# Altium schematic sheet projectnew.schdoc
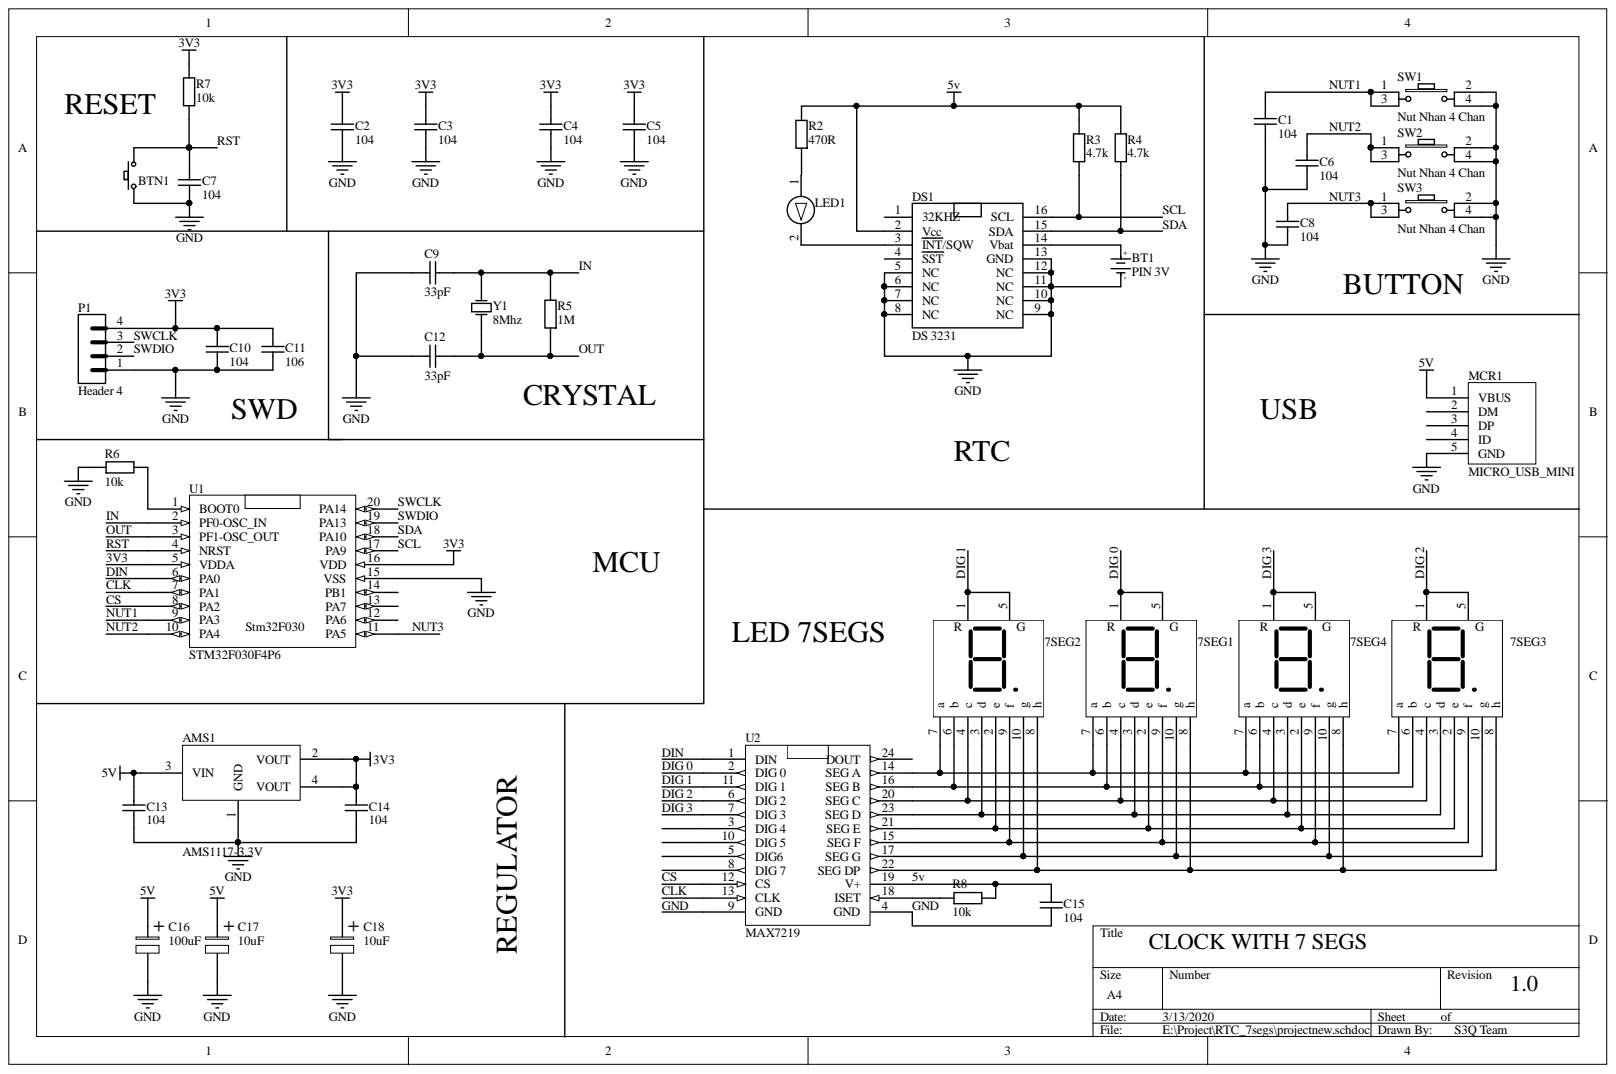
[diagram p0: projectnew.schdoc]

## Parts
7SEG1  Led 7 Thanh 2.3 Inch Anot  [LED 7 THANH X 1.8INCH]  (value not drawn)
7SEG2  Led 7 Thanh 2.3 Inch Anot  [LED 7 THANH X 1.8INCH]  (value not drawn)
7SEG3  Led 7 Thanh 2.3 Inch Anot  [LED 7 THANH X 1.8INCH]  (value not drawn)
7SEG4  Led 7 Thanh 2.3 Inch Anot  [LED 7 THANH X 1.8INCH]  (value not drawn)
AMS1  AMS1117-3.3V  [SOT223-4N]
BT1  PIN 3V  [DE PINCR1220]
BTN1  Button  [SW-SMD]  (value not drawn)
C1  104  [Tu 0805]
C2  104  [tu 0805]
C3  104  [tu 0805]
C4  104  [tu 0805]
C5  104  [tu 0805]
C6  104  [Tu 0805]
C7  104  [tu 0805]
C8  104  [Tu 0805]
C9  33pF  [tu 0805]
C10  104  [tu 0805]
C11  106  [Tu 0805]
C12  33pF  [tu 0805]
C13  104  [tu 0805]
C14  104  [tu 0805]
C15  104  [Tu 0805]
C16  100uF  [tu nhom 6.3 (d)]
C17  10uF  [tu nhom 4 (b)]
C18  10uF  [tu nhom 4 (b)]
DS1  DS 3231  [SOP16]
LED1  (no value)  [led 0805]
MCR1  MICRO_USB_MINI  [micro USB MK5P_new]
P1  Header 4  [JP4]
R2  470R  [Tro SMD 0805]
R3  4.7k  [Tro SMD 0805]
R4  4.7k  [Tro SMD 0805]
R5  1M  [tro smd 0805]
R6  10k  [tro smd 0805]
R7  10k  [tro smd 0805]
R8  10k  [tro smd 0805]
SW1  Nut Nhan 4 Chan  [SW4]
SW2  Nut Nhan 4 Chan  [SW4]
SW3  Nut Nhan 4 Chan  [SW4]
U1  STM32F030F4P6  [TSSOP20]
U2  MAX7219  [SOIC127P1030X265-24M]
Y1  8Mhz  [THACH ANH CAM]

## Nets
3V3: AMS1.2(VOUT) AMS1.4(VOUT) C2.1 C3.1 C4.1 C5.1 C10.1 C11.1 C14.1 C18.1 P1.4 R7.2 U1.5(VDDA) U1.16(VDD)
5v: AMS1.3(VIN) C13.1 C15.2 C16.1 C17.1 DS1.2(Vcc) MCR1.1(VBUS) R2.2 R3.2 R4.2 R8.2 U2.19(V+)
CLK: U1.7(PA1) U2.13(CLK)
CS: U1.8(PA2) U2.12(CS)
DIG 0: 7SEG1.1 7SEG1.5 U2.2(DIG_0)
DIG 1: 7SEG2.1 7SEG2.5 U2.11(DIG_1)
DIG 2: 7SEG3.1 7SEG3.5 U2.6(DIG_2)
DIG 3: 7SEG4.1 7SEG4.5 U2.7(DIG_3)
DIN: U1.6(PA0) U2.1(DIN)
GND: AMS1.1(GND) BT1.2 BTN1.1 C1.2 C2.2 C3.2 C4.2 C5.2 C6.2 C7.2 C8.2 C9.2 C10.2 C11.2 C12.2 C13.2 C14.2 C15.1 C16.2 C17.2 C18.2 DS1.5(NC) DS1.6(NC) DS1.7(NC) DS1.8(NC) DS1.9(NC) DS1.10(NC) DS1.11(NC) DS1.12(NC) DS1.13(GND) MCR1.5(GND) P1.1 R6.1 SW1.2 SW1.4 SW2.2 SW2.4 SW3.2 SW3.4 U1.15(VSS) U2.4(GND) U2.9(GND)
IN: C9.1 R5.2 U1.2(PF0-OSC_IN) Y1.2
NUT1: C1.1 SW1.1 SW1.3 U1.9(PA3)
NUT2: C6.1 SW2.1 SW2.3 U1.10(PA4)
NUT3: C8.1 SW3.1 SW3.3 U1.11(PA5)
OUT: C12.1 R5.1 U1.3(PF1-OSC_OUT) Y1.1
RST: BTN1.2 C7.1 R7.1 U1.4(NRST)
SCL: DS1.16(SCL) R3.1 U1.17(PA9)
SDA: DS1.15(SDA) R4.1 U1.18(PA10)
SWCLK: P1.3 U1.20(PA14)
SWDIO: P1.2 U1.19(PA13)
Net7SEG1_2: 7SEG1.2(e) 7SEG2.2(e) 7SEG3.2(e) 7SEG4.2(e) U2.21(SEG_E)
Net7SEG1_3: 7SEG1.3(d) 7SEG2.3(d) 7SEG3.3(d) 7SEG4.3(d) U2.23(SEG_D)
Net7SEG1_4: 7SEG1.4(c) 7SEG2.4(c) 7SEG3.4(c) 7SEG4.4(c) U2.20(SEG_C)
Net7SEG1_6: 7SEG1.6(b) 7SEG2.6(b) 7SEG3.6(b) 7SEG4.6(b) U2.16(SEG_B)
Net7SEG1_7: 7SEG1.7(a) 7SEG2.7(a) 7SEG3.7(a) 7SEG4.7(a) U2.14(SEG_A)
Net7SEG1_8: 7SEG1.8(h) 7SEG2.8(h) 7SEG3.8(h) 7SEG4.8(h) U2.22(SEG_DP)
Net7SEG1_9: 7SEG1.9(f) 7SEG2.9(f) 7SEG3.9(f) 7SEG4.9(f) U2.15(SEG_F)
Net7SEG1_10: 7SEG1.10(g) 7SEG2.10(g) 7SEG3.10(g) 7SEG4.10(g) U2.17(SEG_G)
NetBT1_1: BT1.1 DS1.14(Vbat)
NetDS1_3: DS1.3(INT/SQW) LED1.2
NetLED1_1: LED1.1 R2.1
NetR6_2: R6.2 U1.1(BOOT0)
NetR8_1: R8.1 U2.18(ISET)

Not connected: DS1 1(32KHZ) 4(SST); MCR1 2(DM) 3(DP) 4(ID); U1 12(PA6) 13(PA7) 14(PB1); U2 3(DIG_4) 5(DIG6) 8(DIG_7) 10(DIG_5) 24(DOUT)

## Library data of the parts
7SEG1-7SEG4 = LED 7SEG 2.3Inch: Single 7 Seg Dipslay 29x41 mm; Value: LED 7S SEG; Component Kind: Standard; Library Name: THU VIEN SCH.SchLib
AMS1 = AMS1117: Dán; Don_Vi: Con; Ma_Hang: MH000596; Value: AMS1117-3.3V SOT223; Chung_Loai: Dán; Component Kind: Standard; Library Name: THU VIEN SCH.SchLib
BT1 = PIN 3V: Battery 3VDC; Component Kind: Standard; Library Name: THU VIEN SCH.SchLib; Value: PIN 3V
C1 C6 C8 C15 = Tu 104: Dán; Don_Vi: Con; Ma_Hang: MH004551; Ten_Linh_Kien: Tụ 0805 104-100nF 10% 50V; Chung_Loai: Dán; Component Kind: Standard; Library Name: THU VIEN SCH.SchLib
C2-C5 C7 C10 C13 C14 = Tu 0805 104 50V 10%: Dán; Don_Vi: Con; Ma_Hang: MH004551; So_Chan: 2; Ten_Linh_Kien: Tụ 0805 104-100nF 10% 50V; Chung_Loai: Dán; Component Kind: Standard; Library Name: THU VIEN SCH.SchLib
C9 C12 = Tu 0805 33pF 50V 10%: Dán; Don_Vi: Con; Ma_Hang: MH004563; So_Chan: 2; Ten_Linh_Kien: Tụ 0805 33p 10% 50V; Chung_Loai: Dán; Component Kind: Standard; Library Name: THU VIEN SCH.SchLib
C11 = Tu 0805 106 16V 10%: Dán; Don_Vi: Con; Ma_Hang: MH004553; So_Chan: 2; Ten_Linh_Kien: Tụ 0805 106-10uF 10% 16V; Chung_Loai: Dán; Component Kind: Standard; Library Name: THU VIEN SCH.SchLib
C16 = Tu Nhom 100uF 16V: Dán; Don_Vi: Con; Ma_Hang: MH002219; So_Chan: 2; Ten_Linh_Kien: Tụ Nhôm 100uF 16V 6.3x5.4MM; Chung_Loai: Dán; Component Kind: Standard; Library Name: THU VIEN SCH.SchLib
C17 C18 = Tu Nhom 10uF 16V: Dán; Don_Vi: Con; Ma_Hang: MH001458; So_Chan: 2; Ten_Linh_Kien: Tụ Nhôm 10uF 16V 4x5MM; Chung_Loai: Dán; Component Kind: Standard; Library Name: THU VIEN SCH.SchLib
DS1 = DS 3231: Dán; Don_Vi: Con; Ma_Hang: MH002604; Ten_Linh_Kien: DS3231N SOIC16; Chung_Loai: Dán; Component Kind: Standard; Library Name: THU VIEN SCH.SchLib
LED1 = LED 3mm Do: Cắm; Don_Vi: Con; Ma_Hang: MH004931; Ten_Linh_Kien: LED 3MM Sáng Đỏ ( SS); Chung_Loai: Cắm; Component Kind: Standard; Library Name: THU VIEN SCH.SchLib
P1 = Header 4: Header, 4-Pin; Component Kind: Standard; LatestRevisionDate: 17-Jul-2002; LatestRevisionNote: Re-released for DXP Platform.; Library Name: PIF_C16_lib.IntLib; Publisher: Altium Limited
R2 = Tro 0603 5% 470R: Dán; Don_Vi: Con; Ma_Hang: MH006328; So_Chan: 2; Ten_Linh_Kien: Trở 0603 5% 470R; Chung_Loai: Dán; Component Kind: Standard; Library Name: THU VIEN SCH.SchLib
R3 R4 = Tro 0603 5% 4.7k: Dán; Don_Vi: Con; Ma_Hang: MH006327; So_Chan: 2; Ten_Linh_Kien: Trở 0603 5% 4.7k; Chung_Loai: Dán; Component Kind: Standard; Library Name: THU VIEN SCH.SchLib
R5 = Tro 0805 5% 1M: Dán; Don_Vi: Con; Ma_Hang: MH005235; So_Chan: 2; Ten_Linh_Kien: Trở 0805 5% 1M; Chung_Loai: Dán; Component Kind: Standard; Library Name: THU VIEN SCH.SchLib
R6-R8 = Tro 0805 5% 10k: Dán; Don_Vi: Con; Ma_Hang: MH005239; So_Chan: 2; Ten_Linh_Kien: Trở 0805 5% 10k; Chung_Loai: Dán; Component Kind: Standard; Library Name: THU VIEN SCH.SchLib
SW1-SW3 = Nut Nhan 4 Chan: Cắm; Don_Vi: Con; Ma_Hang: MH004077; Value: Nút Nhấn 4 Chân 6x6x9MM DIP; Component Kind: Standard; Library Name: THU VIEN SCH.SchLib
U1 = STM32F030F4P6: TSSOP20 Package; Component Kind: Standard; Library Name: My_lib.schlib
Y1 = Thach Anh 8Mhz SMD: Dán; Don_Vi: Con; Ma_Hang: MH005038; Ten_Linh_Kien: Thạch Anh 8Mhz SMD5032; Chung_Loai: Dán; Component Kind: Standard; Library Name: THU VIEN SCH.SchLib
BTN1 = Button: Component Kind: Standard; Library Name: PIF_C16_lib.IntLib
MCR1 = MICRO_USB_MINI: Component Kind: Standard; Library Name: THU VIEN SCH.SchLib
U2 = MAX7219: Component Kind: Standard; Library Name: My_lib.SchLib
